# Altium schematic sheet Bitcoiner_EB_Project_V1I1_Schematic.SchDoc (continued)
## Library data of the parts (continued)
SW1-SW4 = OS102011MS2QN1: Slide; Manufacturer: ITT C&K; Actuator Color: Black; Actuator Length: 4mm; Actuator Material: Polyoxymethylene,Acetal,Polyacetal; Actuator Type: Standard; Contact Current Rating: 100mA; Contact Resistance: 20mR; Contact Style: Non-Shorting; Electrical Life: 10000Cycles; Insulation Resistance: 100MR; Max Voltage Rating (DC): 12V; Switch Function: On-On; Switch Travel: 2mm; Throw Configuration: SPDT; Category: Slide Switches; Component Kind: Standard; ComponentLink1Description: https://datasheet.ciiva.com/16298/os102011ms2qn1-16298526.pdf?src-supplier=Future+Electronics; ComponentLink1URL: https://datasheet.ciiva.com/16298/os102011ms2qn1-16298526.pdf?src-supplier=Future+Electronics; ComponentLink2Description: https://datasheet.ciiva.com/30466/0900766b81263f61-30466806.pdf?src-supplier=RSComponents; ComponentLink2URL: https://datasheet.ciiva.com/30466/0900766b81263f61-30466806.pdf?src-supplier=RSComponents; ComponentLink3Description: https://datasheet.ciiva.com/1532/1683358-1532308.pdf?src-supplier=Element14; ComponentLink3URL: https://datasheet.ciiva.com/1532/1683358-1532308.pdf?src-supplier=Element14; ComponentLink4Description: https://datasheet.ciiva.com/2889/os-19jan15-2889173.pdf?src-supplier=Digi-Key; ComponentLink4URL: https://datasheet.ciiva.com/2889/os-19jan15-2889173.pdf?src-supplier=Digi-Key; ComponentLink5Description: https://datasheet.ciiva.com/1699/os-19jan15-1699479.pdf?src-supplier=Newark; ComponentLink5URL: https://datasheet.ciiva.com/1699/os-19jan15-1699479.pdf?src-supplier=Newark; ComponentLink6Description: https://datasheet.ciiva.com/18147/getdatasheetpartid-320490-18147210.pdf?src-supplier=Verical; ComponentLink6URL: https://datasheet.ciiva.com/18147/getdatasheetpartid-320490-18147210.pdf?src-supplier=Verical; ComponentLink7Description: https://datasheet.ciiva.com/16997/70128245-16997771.pdf?src-supplier=Allied+Electronics; ComponentLink7URL: https://datasheet.ciiva.com/16997/70128245-16997771.pdf?src-supplier=Allied+Electronics; ComponentLink8Description: https://datasheet.ciiva.com/26290/os-11mar13-26290652.pdf?src-supplier=Onlinecomponents.com; ComponentLink8URL: https://datasheet.ciiva.com/26290/os-11mar13-26290652.pdf?src-supplier=Onlinecomponents.com; Contact Material: Copper Alloy,Brass,Silver; Contact Plating: Silver,Gold; Current Rating: 100mA; Depth: 4.3mm; Housing Material: Resin; Lead Free: Lead Free; Length: 8.6mm; Manufacturer Part Number: OS102011MS2QN1; Max Current Rating: 100mA; Max Operating Temperature: 70°C; Mechanical Life: 10000Cycles; Min Operating Temperature: -30°C; Mount: Through Hole,PCB; Orientation: Vertical; Package Quantity: 300; Packaging: Bulk; Pricing 1: 1=0.39000, 10=0.39000, 50=0.34400, 100=0.32100, 1000=0.26400, 10000=0.24300 (USD); Radiation Hardening: No; REACH SVHC: No SVHC; RoHS: Yes; RoHS Compliant: Yes; Series: OS; Stock 1: 6258; Supplier 1: Mouser; Supplier Part Number 1: 611-OS102011MS2QN1; Termination: PC Pins; Voltage Rating (DC): 12V; Library Name: Bitcoiner_EB_Project_V1I1.SchLib
U1 = FT2232HL-REEL: Integrated Circuit; Manufacturer_Name: FTDI Chip; Component Kind: Standard; Datasheet Link: https://componentsearchengine.com//FT2232HL-REEL.pdf; Height: 1.6mm; Manufacturer_Part_Number: FT2232HL-REEL; Mouser2 Part Number: 895-FT2232HL; Mouser2 Price/Stock: https://www.mouser.com/Search/Refine.aspx?Keyword=895-FT2232HL; Library Name: Bitcoiner_EB_Project_V1I1.SchLib
U2 U7 = MCP1825ST-3302E/DB: IC REG LIN 3.3V 500MA SOT223-3; Manufacturer: Microchip; Dropout Voltage: 210mV; Input Bias Current: 120uA; Max Input Voltage: 6V; Min Input Voltage: 2.1V; Number of Outputs: 1; Output Type: Fixed; Output Voltage Accuracy: 0.5%; Polarity: Positive; Quiescent Current: 220uA; Case/Package: SOT-223; Category: Voltage Regulators - Linear; Component Kind: Standard; ComponentLink1Description: https://datasheet.ciiva.com/8238/22056b-8238778.pdf?src-supplier=Avnet; ComponentLink1URL: https://datasheet.ciiva.com/8238/22056b-8238778.pdf?src-supplier=Avnet; ComponentLink2Description: https://datasheet.ciiva.com/2056/22056b-2056427.pdf?src-supplier=Digi-Key; ComponentLink2URL: https://datasheet.ciiva.com/2056/22056b-2056427.pdf?src-supplier=Digi-Key; Manufacturer Part Number: MCP1825ST-3302E/DB; Max Operating Temperature: 125°C; Min Operating Temperature: -40°C; Mount: Surface Mount; Number of Pins: 3; Number of Regulators: 1; Output Current: 500mA; Output Voltage: 3.3V; Package Quantity: 4000; Packaging: Tape and Reel; Pricing 1: 1=0.57000, 10=0.56700, 50=0.47300, 100=0.43100, 1000=0.43100, 10000=0.43000 (USD); Radiation Hardening: No; RoHS: Yes; RoHS Compliant: Yes; Series: -; Stock 1: 7744; Supplier 1: Mouser; Supplier Part Number 1: 579-MCP1825ST3302EDB; Library Name: Bitcoiner_EB_Project_V1I1.SchLib
U3 = 93LC56BT-I/OT: MICROCHIP - 93LC56BT-I/OT. - 2K, 128 X 16, SERIAL EE, IND ROHS COMPLIANT: YES; Access Time: 250ns; Density: 2Kb; Frequency: 2MHz; Max Supply Voltage: 5.5V; Memory Type: EEPROM; Min Supply Voltage: 2.5V; Nominal Supply Current: 2mA; Case/Package: SOT-23; Category: EEPROM; Component Kind: Standard; ComponentLink1Description: https://datasheet.ciiva.com/48496/jp013939-48496476.pdf?src-supplier=Avnet; ComponentLink1URL: https://datasheet.ciiva.com/48496/jp013939-48496476.pdf?src-supplier=Avnet; ComponentLink2Description: https://datasheet.ciiva.com/16216/filehandler-aspxddocname-en013939-16216574.pdf?src-supplier=Future+Electronics; ComponentLink2URL: https://datasheet.ciiva.com/16216/filehandler-aspxddocname-en013939-16216574.pdf?src-supplier=Future+Electronics; ComponentLink3Description: https://datasheet.ciiva.com/3185/jp013939-3185519.pdf?src-supplier=Avnet; ComponentLink3URL: https://datasheet.ciiva.com/3185/jp013939-3185519.pdf?src-supplier=Avnet; ComponentLink4Description: https://datasheet.ciiva.com/16622/jp013939-16622127.pdf?src-supplier=Avnet+Europe; ComponentLink4URL: https://datasheet.ciiva.com/16622/jp013939-16622127.pdf?src-supplier=Avnet+Europe; Contact Plating: Tin,Matte; Height: 1.3mm; Interface: Serial; Lead Free: Lead Free; Length: 3.1mm; Manufacturer: Microchip; Manufacturer Part Number: 93LC56BT-I/OT; Max Frequency: 2MHz; Max Operating Temperature: 85°C; Memory Size: 2Kb; Min Operating Temperature: -40°C; Mount: Surface Mount; Number of Pins: 6; Package Quantity: 3000; Packaging: Tape and Reel; Pricing 1: 1=0.25000, 10=0.24200, 50=0.23100, 100=0.22100, 1000=0.22100, 10000=0.22100 (USD); Radiation Hardening: No; REACH SVHC: No SVHC; RoHS: No; Stock 1: 38253; Supplier 1: Mouser; Supplier Part Number 1: 579-93LC56BT-I/OT; Width: 1.8mm; Library Name: Bitcoiner_EB_Project_V1I1.SchLib
U4 = LSF0102DPH: Manufacturer: Nexperia; Component Kind: Standard; Manufacturer Part Number: LSF0102DPH; Pricing 1: 1=0.58000, 10=0.48100, 50=0.48100, 100=0.36500, 1000=0.24800, 10000=0.20100 (USD); RoHS: No; Stock 1: 2386; Supplier 1: Mouser; Supplier Part Number 1: 771-LSF0102DPH; Library Name: Bitcoiner_EB_Project_V1I1.SchLib
U5 = 74LVC1G07GW,125: NEXPERIA - 74LVC1G07GW,125 - BUFFER, NON-INVERTING, TSSOP-5; Category: Logic Gates; Component Kind: Standard; ComponentLink1Description: http://www.farnell.com/datasheets/2185287.pdf?src-supplier=Farnell; ComponentLink1URL: http://www.farnell.com/datasheets/2185287.pdf?src-supplier=Farnell; ComponentLink2Description: https://assets.nexperia.com/documents/data-sheet/74LVC1G07.pdf?src-supplier=Digi-Key; ComponentLink2URL: https://assets.nexperia.com/documents/data-sheet/74LVC1G07.pdf?src-supplier=Digi-Key; ComponentLink3Description: http://www.farnell.com/datasheets/2185287.pdf?src-supplier=Newark; ComponentLink3URL: http://www.farnell.com/datasheets/2185287.pdf?src-supplier=Newark; Manufacturer: Nexperia; Manufacturer Part Number: 74LVC1G07GW,125; Pricing 1: 1=0.24000, 10=0.16400, 50=0.16400, 100=0.08100, 1000=0.04700, 10000=0.03600 (USD); RoHS: Yes; RoHS Compliant: Yes; Stock 1: 3142; Supplier 1: Mouser; Supplier Part Number 1: 771-74LVC1G07GW-G; Library Name: Bitcoiner_EB_Project_V1I1.SchLib
U6 = TPS562202SDRLR: Manufacturer: Texas Instruments; Component Kind: Standard; Manufacturer Part Number: TPS562202SDRLR; Pricing 1: 1=0.62000, 10=0.54600, 50=0.54600, 100=0.41900, 1000=0.26500, 10000=0.21800 (USD); RoHS: Yes; Stock 1: 1059; Supplier 1: Mouser; Supplier Part Number 1: 595-TPS562202SDRLR; Library Name: Bitcoiner_EB_Project_V1I1.SchLib
Y1 = ABLS7M2-12.000MHZ-D-2Y-T: CRYSTAL 12.0000MHZ 18PF SMD; Manufacturer: Abracon; ESR (Equivalent Series Resistance): 60R; Frequency: 12MHz; Frequency Stability: 30ppm; Frequency Tolerance: 20ppm; Case/Package: SMD/SMT; Category: Crystals; Component Kind: Standard; ComponentLink1Description: http://www.farnell.com/datasheets/2608288.pdf?src-supplier=Farnell; ComponentLink1URL: http://www.farnell.com/datasheets/2608288.pdf?src-supplier=Farnell; ComponentLink2Description: http://www.mouser.com/ds/2/3/ABLS7M2-1003668.pdf?src-supplier=Mouser; ComponentLink2URL: http://www.mouser.com/ds/2/3/ABLS7M2-1003668.pdf?src-supplier=Mouser; ComponentLink3Description: http://www.farnell.com/datasheets/1883657.pdf?src-supplier=Element14; ComponentLink3URL: http://www.farnell.com/datasheets/1883657.pdf?src-supplier=Element14; ComponentLink4Description: http://abracon.com/Resonators/ABLS7M2.pdf?src-supplier=Digi-Key; ComponentLink4URL: http://abracon.com/Resonators/ABLS7M2.pdf?src-supplier=Digi-Key; ComponentLink5Description: https://abracon.com/Resonators/ABLS7M2.pdf?src-supplier=Digi-Key; ComponentLink5URL: https://abracon.com/Resonators/ABLS7M2.pdf?src-supplier=Digi-Key; Height: 0.079inch; Lead Free: Lead Free; Length: 0.276inch; Load Capacitance: 18pF; Manufacturer Part Number: ABLS7M2-12.000MHZ-D-2Y-T; Max Operating Temperature: 85°C; Min Operating Temperature: -40°C; Mount: Surface Mount; Package Quantity: 3000; Packaging: Tape and Reel; Pricing 1: 1=0.37000, 10=0.31100, 50=0.27900, 100=0.24800, 1000=0.18900, 10000=0.18900 (USD); REACH SVHC: Yes; RoHS: Yes; Stock 1: 6312; Supplier 1: Mouser; Supplier Part Number 1: 815-ABLS7M212MHZD2YT; Termination: SMD/SMT; Tolerance: 20ppm; Width: 0.161inch; Library Name: Bitcoiner_EB_Project_V1I1.SchLib
J3 J6 J8 = CON_2X10_2.0MM_RA: Component Kind: Standard; Library Name: Bitcoiner_EB_Project_V1I1.SchLib
